# Altium schematic sheet zigbee.SchDoc
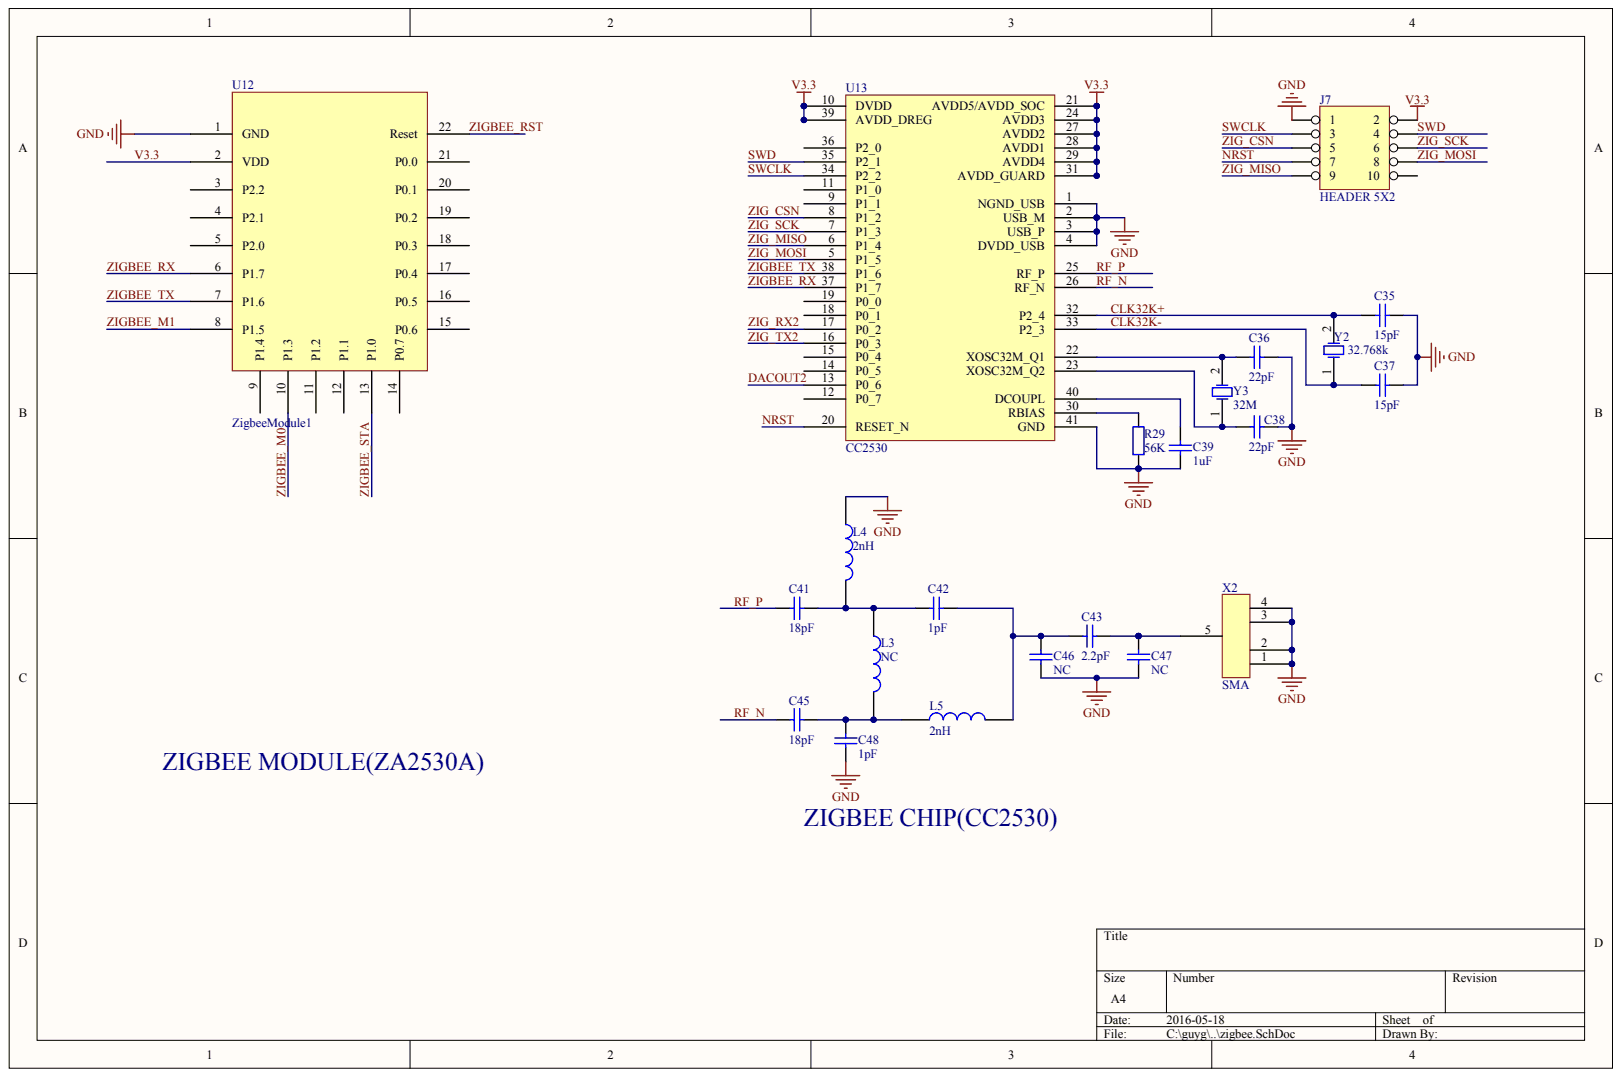
[diagram p0: zigbee.SchDoc]

## Parts
C35  15pF
C36  22pF
C37  15pF
C38  22pF
C39  1uF
C41  18pF
C42  1pF
C43  2.2pF
C45  18pF
C46  NC
C47  NC
C48  1pF
J7  HEADER 5X2
L3  NC
L4  2nH
L5  2nH
R29  56K
U12  ZigbeeModule1
U13  CC2530
X2  SMA
Y2  32.768k
Y3  32M

## Nets
GND: C35.2 C36.1 C37.2 C38.1 C39.2 C46.2 C47.1 C48.2 J7.1 L4.1 R29.2 U12.1(GND) U13.1(NGND_USB) U13.2(USB_M) U13.3(USB_P) U13.4(DVDD_USB) U13.41(GND) X2.1 X2.2 X2.3 X2.4
CLK32K+: C35.1 U13.32(P2_4) Y2.2
CLK32K-: C37.1 U13.33(P2_3) Y2.1
DACOUT2: U13.13(P0_6)
NRST: J7.7 U13.20(RESET_N)
RF_N: C45.2 U13.26(RF_N)
RF_P: C41.2 U13.25(RF_P)
SWCLK: J7.3 U13.34(P2_2)
SWD: J7.4 U13.35(P2_1)
V3.3: J7.2 U12.2(VDD) U13.10(DVDD) U13.21(AVDD5/AVDD_SOC) U13.24(AVDD3) U13.27(AVDD2) U13.28(AVDD1) U13.29(AVDD4) U13.31(AVDD_GUARD) U13.39(AVDD_DREG)
ZIG_CSN: J7.5 U13.8(P1_2)
ZIG_MISO: J7.9 U13.6(P1_4)
ZIG_MOSI: J7.8 U13.5(P1_5)
ZIG_RX2: U13.17(P0_2)
ZIG_SCK: J7.6 U13.7(P1_3)
ZIG_TX2: U13.16(P0_3)
ZIGBEE_M0: U12.10(P1.3)
ZIGBEE_M1: U12.8(P1.5)
ZIGBEE_RST: U12.22(Reset)
ZIGBEE_RX: U12.6(P1.7) U13.37(P1_7)
ZIGBEE_STA: U12.13(P1.0)
ZIGBEE_TX: U12.7(P1.6) U13.38(P1_6)
NetC36_2: C36.2 U13.22(XOSC32M_Q1) Y3.2
NetC38_2: C38.2 U13.23(XOSC32M_Q2) Y3.1
NetC39_1: C39.1 U13.40(DCOUPL)
NetC41_1: C41.1 C42.1 L3.1 L4.2
NetC42_2: C42.2 C43.1 C46.1 L5.2
NetC43_2: C43.2 C47.2 X2.5
NetC45_1: C45.1 C48.1 L3.2 L5.1
NetR29_1: R29.1 U13.30(RBIAS)

Not connected: J7 10; U12 3(P2.2) 4(P2.1) 5(P2.0) 9(P1.4) 11(P1.2) 12(P1.1) 14(P0.7) 15(P0.6) 16(P0.5) 17(P0.4) 18(P0.3) 19(P0.2) 20(P0.1) 21(P0.0); U13 9(P1_1) 11(P1_0) 12(P0_7) 14(P0_5) 15(P0_4) 18(P0_1) 19(P0_0) 36(P2_0)

## Library data of the parts
C39 C41-C43 C45-C48 = (no symbol name): Value: 22pF; Published: 8-Jun-2000; LatestRevisionDate: 17-Jul-2002; LatestRevisionNote: Re-released for DXP Platform.; PackageReference: RAD-0.3; Publisher: Altium Limited
R29 = (no symbol name): Value: 1K; Published: 8-Jun-2000; LatestRevisionDate: 17-Jul-2002; LatestRevisionNote: Re-released for DXP Platform.; PackageReference: AXIAL-0.4; Publisher: Altium Limited
C35-C38 J7 L3-L5 U12 U13 X2 Y2 Y3 = (no symbol name)
  C35 C37: Published: 8-Jun-2000; LatestRevisionDate: 17-Jul-2002; LatestRevisionNote: Re-released for DXP Platform.; PackageReference: RAD-0.3; Value: 15pF
  C36 C38: Published: 8-Jun-2000; LatestRevisionDate: 17-Jul-2002; LatestRevisionNote: Re-released for DXP Platform.; PackageReference: RAD-0.3; Value: 22pF
  Y2 Y3: Published: 8-Jun-2000; LatestRevisionDate: 17-Jul-2002; LatestRevisionNote: Re-released for DXP Platform.; PackageReference: R38
  C35-C38 Y2 Y3: Publisher: Altium Limited
